# Altium schematic sheet 1.SchDoc
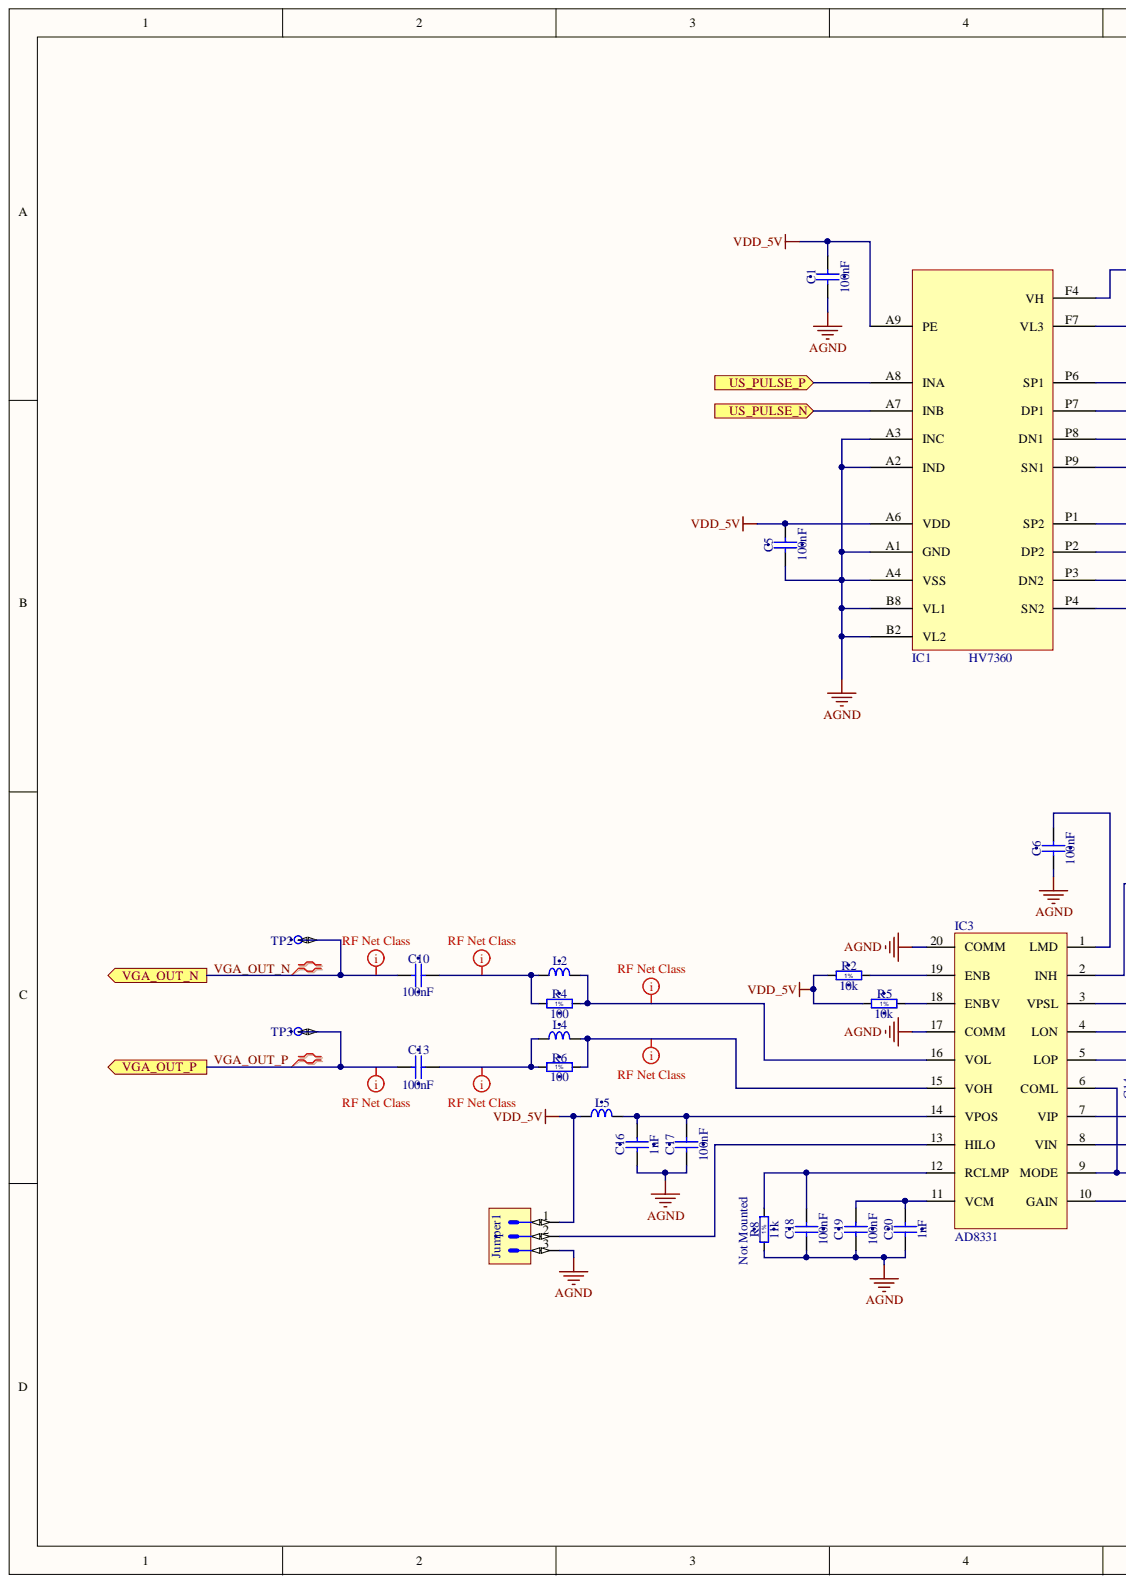
[diagram p0: 1.SchDoc, left]
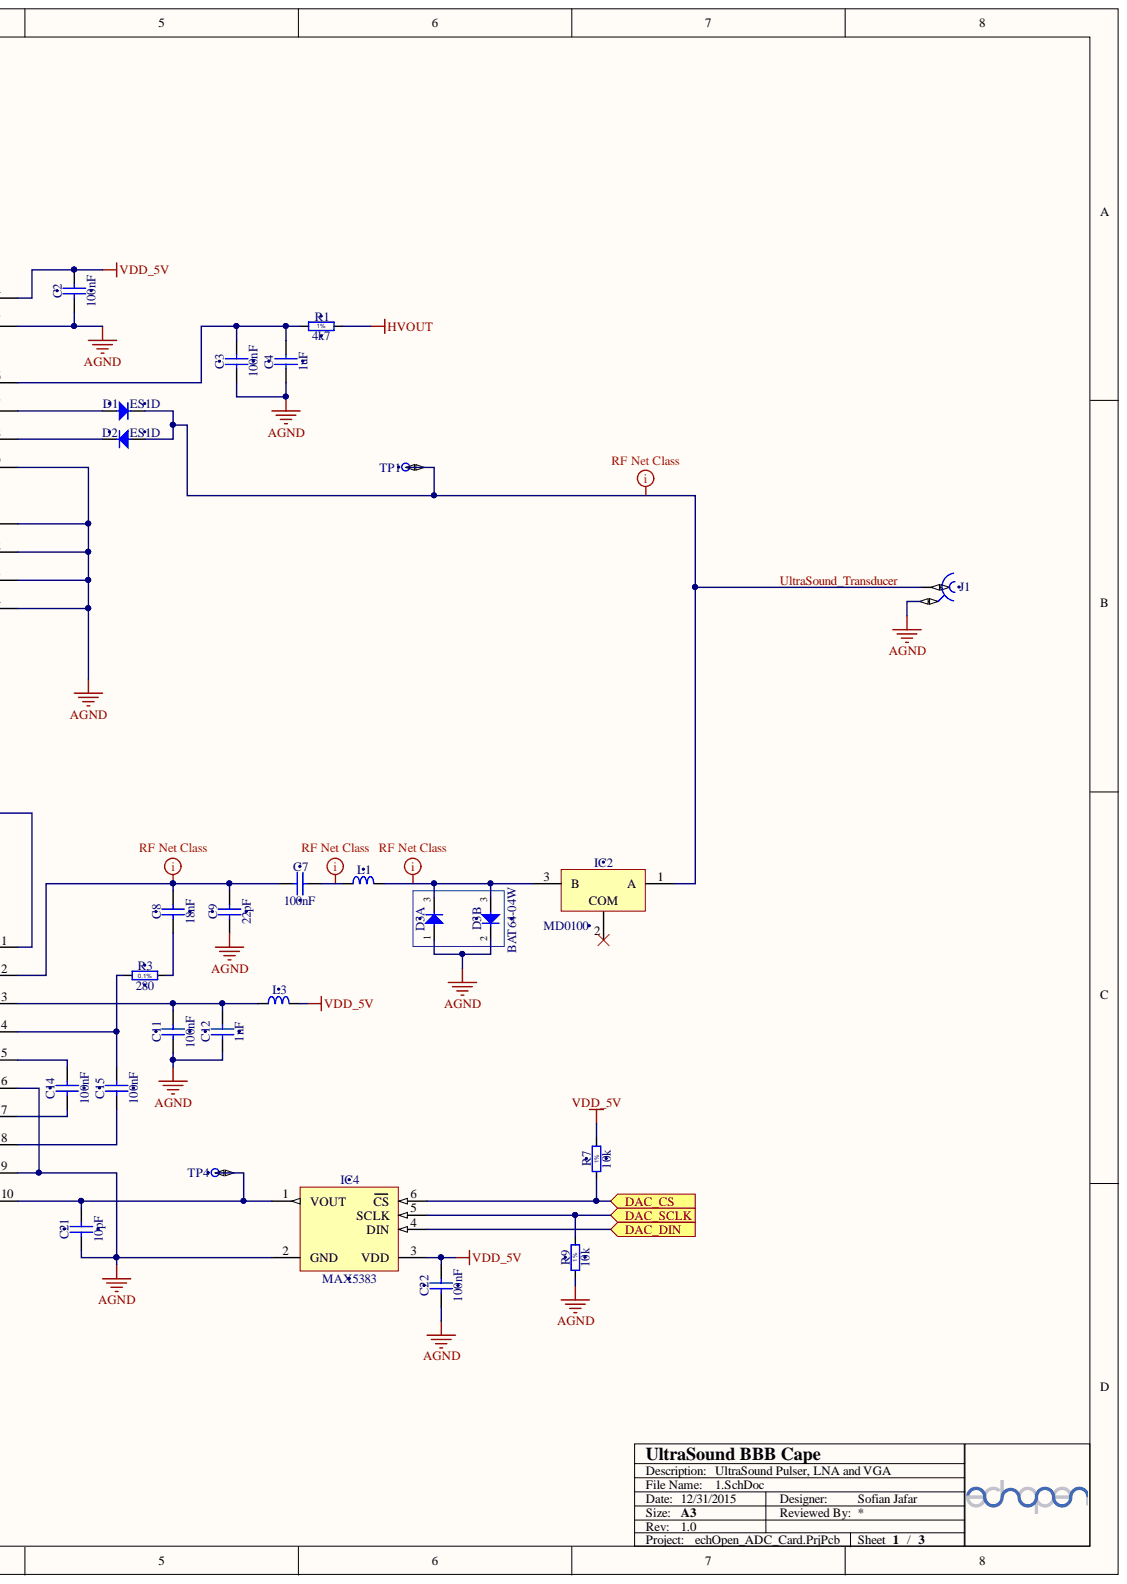
[diagram p1: 1.SchDoc, right]

## Parts
C1  100nF  [CAPC1608X87N]
C2  100nF  [CAPC1608X87N]
C3  100nF  [CAPC1608X87N]
C4  1uF  [CAPC5650X165N]
C5  100nF  [CAPC1608X87N]
C6  100nF  [CAPC1608X87N]
C7  100nF  [CAPC1608X87N]
C8  18nF  [CAPC1608X87N]
C9  22pF  [CAPC1608X87N]
C10  100nF  [CAPC1608X87N]
C11  100nF  [CAPC1608X87N]
C12  1nF  [CAPC1608X87N]
C13  100nF  [CAPC1608X87N]
C14  100nF  [CAPC1608X87N]
C15  100nF  [CAPC1608X87N]
C16  1nF  [CAPC1608X87N]
C17  100nF  [CAPC1608X87N]
C18  100nF  [CAPC1608X87N]
C19  100nF  [CAPC1608X87N]
C20  1nF  [CAPC1608X87N]
C21  10pF  [CAPC1608X87N]
C22  100nF  [CAPC1608X87N]
D1  ES1D  [DIOM5027X244N]
D2  ES1D  [DIOM5027X244N]
D3  BAT64-04W  [SOT65P210X100-3N]  (parts A,B)
IC1  HV7360  [HV7360]
IC2  MD0100  [SOT89]
IC3  AD8331  [SOP64P600X175-20N]
IC4  MAX5383  [SOT65P210X100-6N]
J1  SMA  [AMPHENOL_132357-11]  (value not drawn)
Jumper1  Family  [SULLINS_GRPB031VWVN]  (value not drawn)
L1  75R@100MHz  [INDC2012X105N]  (value not drawn)
L2  75R@100MHz  [INDC2012X105N]  (value not drawn)
L3  75R@100MHz  [INDC2012X105N]  (value not drawn)
L4  75R@100MHz  [INDC2012X105N]  (value not drawn)
L5  75R@100MHz  [INDC2012X105N]  (value not drawn)
R1  4k7  [RESC3216X65N]
R2  10k  [RESC1608X55N]
R3  280  [RESC1608X55N]
R4  100  [RESC1608X55N]
R5  10k  [RESC1608X55N]
R6  100  [RESC1608X55N]
R7  10k  [RESC1608X55N]
R8  11k  Mounted: Not Mounted  [RESC1005X40N]
R9  10k  [RESC1608X55N]
TP1  Test Point  [NICOMATIC_C12000B]  (value not drawn)
TP2  Test Point  [NICOMATIC_C12000B]  (value not drawn)
TP3  Test Point  [NICOMATIC_C12000B]  (value not drawn)
TP4  Test Point  [NICOMATIC_C12000B]  (value not drawn)

## Ports
DAC_CS, DAC_DIN, DAC_SCLK, US_PULSE_N, US_PULSE_P, VGA_OUT_N, VGA_OUT_P

## Nets
AGND: C1.1 C2.1 C3.1 C4.1 C5.1 C6.2 C9.1 C11.1 C12.1 C16.1 C17.2 C18.2 C19.2 C20.1 C21.1 C22.1 D3.1 D3.2 IC1.A1(GND) IC1.A2(IND) IC1.A3(INC) IC1.A4(VSS) IC1.B2(VL2) IC1.B8(VL1) IC1.F7(VL3) IC1.P1(SP2) IC1.P2(DP2) IC1.P3(DN2) IC1.P4(SN2) IC1.P9(SN1) IC3.6(COML) IC3.9(MODE) IC3.17(COMM) IC3.20(COMM) IC4.2(GND) J1.2 Jumper1.3 R8.1 R9.1
HVOUT: R1.2
VDD_5V: C1.2 C2.2 C5.2 C22.2 IC1.A6(VDD) IC1.A9(PE) IC1.F4(VH) IC4.3(VDD) Jumper1.1 L3.2 L5.1 R2.1 R5.1 R7.2
DAC_CS: IC4.6(CS) R7.1 ; port DAC_CS
DAC_DIN: IC4.4(DIN) ; port DAC_DIN
DAC_SCLK: IC4.5(SCLK) R9.2 ; port DAC_SCLK
ULTRASOUND_TRANSDUCER: D1.1 D2.2 IC2.1 J1.1 TP1.1
US_PULSE_N: IC1.A7(INB) ; port US_PULSE_N
US_PULSE_P: IC1.A8(INA) ; port US_PULSE_P
VGA_OUT_N: C10.2 TP2.1 ; port VGA_OUT_N
VGA_OUT_P: C13.2 TP3.1 ; port VGA_OUT_P
NetC3_2: C3.2 C4.2 IC1.P6(SP1) R1.1
NetC6_1: C6.1 IC3.1(LMD)
NetC7_1: C7.1 L1.1
NetC7_2: C7.2 C8.2 C9.2 IC3.2(INH)
NetC8_1: C8.1 R3.2
NetC10_1: C10.1 L2.1 R4.1
NetC11_2: C11.2 C12.2 IC3.3(VPSL) L3.1
NetC13_1: C13.1 L4.1 R6.1
NetC14_1: C14.1 IC3.5(LOP)
NetC14_2: C14.2 IC3.7(VIP)
NetC15_1: C15.1 IC3.4(LON) R3.1
NetC15_2: C15.2 IC3.8(VIN)
NetC16_2: C16.2 C17.1 IC3.14(VPOS) L5.2
NetC18_1: C18.1 IC3.12(RCLMP) R8.2
NetC19_1: C19.1 C20.2 IC3.11(VCM)
NetC21_2: C21.2 IC3.10(GAIN) IC4.1(VOUT) TP4.1
NetD1_2: D1.2 IC1.P7(DP1)
NetD2_1: D2.1 IC1.P8(DN1)
NetD3_3: D3.3 IC2.3 L1.2
NetIC3_13: IC3.13(HILO) Jumper1.2
NetIC3_15: IC3.15(VOH) L4.2 R6.2
NetIC3_16: IC3.16(VOL) L2.2 R4.2
NetIC3_18: IC3.18(ENBV) R5.2
NetIC3_19: IC3.19(ENB) R2.2

Not connected: IC2 2

## Library data of the parts
C1-C3 C5-C7 C10 C11 C13-C15 C17-C19 C22 = CC0603_100NF_50V_10%_X7R: Non-polarised capacitor; Manufacturer: GENERIC; Manufacturer1 Part Number: 223858615649; Part Description: SMD Multilayer Chip Ceramic Capacitor; Database Table Name: Capacitors SMD; TC: X7R; Tolerance: ±10%; Voltage: 50V; Case: 0603; Component Kind: Standard; Component Type: Standard; CreateDate: 12/3/2007; HelpURL: \\cern.ch\dfs\Applications\Altium\Datasheets\CC0603_X7R_PHYCOMP.pdf; LatestRevisionDate: 12/3/2007; Manufacturer1 ComponentHeight: 0.87mm; Manufacturer1 Example: PHYCOMP; Manufacturer Part Number: CC0603_100NF_50V_10%_X7R; Mounted: Yes; Part Number: CC0603_100NF_50V_10%_X7R; Pin Count: 2; SMD: Yes; Socket: No; Status: Preferred; Table: Capacitors SMD; Value: 100nF; Author: CERN DEM JLC; Library Name: Sof-Capacitors.DbLib
C4 = CC2220_1UF_200V_10%_X7R: Non-polarised capacitor; Manufacturer: GENERIC; Manufacturer1 Part Number: C2220X105K2RAC; Part Description: SMD Ceramic Chip Capacitor; Database Table Name: Capacitors SMD; TC: X7R; Tolerance: ±10%; Voltage: 200V; Case: 2220; Component Kind: Standard; Component Type: Standard; CreateDate: 9/16/2009; HelpURL: \\cern.ch\dfs\Applications\Altium\Datasheets\CC2220_X7R_KEMET.pdf; LatestRevisionDate: 9/16/2009; Manufacturer1 ComponentHeight: 1.65mm; Manufacturer1 Example: KEMET; Manufacturer Part Number: CC2220_1UF_200V_10%_X7R; Mounted: Yes; Part Number: CC2220_1UF_200V_10%_X7R; Pin Count: 2; SMD: Yes; Socket: No; Status: None; Table: Capacitors SMD; Value: 1uF; Author: CERN DEM JLC; Library Name: Sof-Capacitors.DbLib
C8 = CC0603_18NF_50V_10%_X7R: Non-polarised capacitor; Manufacturer: GENERIC; Manufacturer1 Part Number: 223858615639; Part Description: SMD Multilayer Chip Ceramic Capacitor; Database Table Name: Capacitors SMD; TC: X7R; Tolerance: ±10%; Voltage: 50V; Case: 0603; Component Kind: Standard; Component Type: Standard; CreateDate: 12/3/2007; HelpURL: \\cern.ch\dfs\Applications\Altium\Datasheets\CC0603_X7R_PHYCOMP.pdf; LatestRevisionDate: 12/3/2007; Manufacturer1 ComponentHeight: 0.87mm; Manufacturer1 Example: PHYCOMP; Manufacturer Part Number: CC0603_18NF_50V_10%_X7R; Mounted: Yes; Part Number: CC0603_18NF_50V_10%_X7R; Pin Count: 2; SMD: Yes; Socket: No; Status: Preferred; Table: Capacitors SMD; Value: 18nF; Author: CERN DEM JLC; Library Name: Sof-Capacitors.DbLib
C9 = CC0603_22PF_50V_5%_NP0: Non-polarised capacitor; Manufacturer: GENERIC; Manufacturer1 Part Number: 223886715229; Part Description: SMD Multilayer Chip Ceramic Capacitor; Database Table Name: Capacitors SMD; TC: NP0; Tolerance: ±5%; Voltage: 50V; Case: 0603; Component Kind: Standard; Component Type: Standard; CreateDate: 12/3/2007; HelpURL: \\cern.ch\dfs\Applications\Altium\Datasheets\CC0603_NP0_PHYCOMP.pdf; LatestRevisionDate: 12/3/2007; Manufacturer1 ComponentHeight: 0.87mm; Manufacturer1 Example: PHYCOMP; Manufacturer Part Number: CC0603_22PF_50V_5%_NP0; Mounted: Yes; Part Number: CC0603_22PF_50V_5%_NP0; Pin Count: 2; SMD: Yes; Socket: No; Status: Preferred; Table: Capacitors SMD; Value: 22pF; Author: CERN DEM JLC; Library Name: Sof-Capacitors.DbLib
C12 C16 C20 = CC0603_1NF_50V_10%_X7R: Non-polarised capacitor; Manufacturer: GENERIC; Manufacturer1 Part Number: 223858615623; Part Description: SMD Multilayer Chip Ceramic Capacitor; Database Table Name: Capacitors SMD; TC: X7R; Tolerance: ±10%; Voltage: 50V; Case: 0603; Component Kind: Standard; Component Type: Standard; CreateDate: 12/3/2007; HelpURL: \\cern.ch\dfs\Applications\Altium\Datasheets\CC0603_X7R_PHYCOMP.pdf; LatestRevisionDate: 12/3/2007; Manufacturer1 ComponentHeight: 0.87mm; Manufacturer1 Example: PHYCOMP; Manufacturer Part Number: CC0603_1NF_50V_10%_X7R; Mounted: Yes; Part Number: CC0603_1NF_50V_10%_X7R; Pin Count: 2; SMD: Yes; Socket: No; Status: Preferred; Table: Capacitors SMD; Value: 1nF; Author: CERN DEM JLC; Library Name: Sof-Capacitors.DbLib
C21 = CC0603_10PF_50V_5%_NP0: Non-polarised capacitor; Manufacturer: GENERIC; Manufacturer1 Part Number: 223886715109; Part Description: SMD Multilayer Chip Ceramic Capacitor; Database Table Name: Capacitors SMD; TC: NP0; Tolerance: ±5%; Voltage: 50V; Case: 0603; Component Kind: Standard; Component Type: Standard; CreateDate: 12/3/2007; HelpURL: \\cern.ch\dfs\Applications\Altium\Datasheets\CC0603_NP0_PHYCOMP.pdf; LatestRevisionDate: 12/3/2007; Manufacturer1 ComponentHeight: 0.87mm; Manufacturer1 Example: PHYCOMP; Manufacturer Part Number: CC0603_10PF_50V_5%_NP0; Mounted: Yes; Part Number: CC0603_10PF_50V_5%_NP0; Pin Count: 2; SMD: Yes; Socket: No; Status: Preferred; Table: Capacitors SMD; Value: 10pF; Author: CERN DEM JLC; Library Name: Sof-Capacitors.DbLib
D1 D2 = ES1D: Diode; Manufacturer: FAIRCHILD SEMICONDUCTOR; Part Description: Fast Rectifier; Database Table Name: Diodes; Power: 1A; Voltage: 200V; Case: DO-214AC; ComponentHeight: 2.44mm; Component Kind: Standard; Component Type: Standard; CreateDate: 5/6/2008; Device: ES1D; HelpURL: \\cern.ch\dfs\Applications\Altium\Datasheets\ES1D.pdf; LatestRevisionDate: 5/6/2008; Manufacturer Part Number: ES1D; Mounted: Yes; PackageDescription: DO-214-AC/SMA  Molded Diode, Body 5.0x2.7mm, IPC Medium Density; Part Number: ES1D; Pin Count: 2; SMD: Yes; Socket: No; Table: Diodes; Author: CERN DEM JLC; Library Name: Sof-ICs And Semiconductors.DbLib
D3 = BAT64-04W: Serial Diode; Manufacturer: INFINEON; Part Description: Silicon Schottky Double Diode; Database Table Name: Diodes; Power: 250mW; Voltage: 40V; Case: SOT323; ComponentHeight: 1mm; Component Kind: Standard; Component Type: Standard; CreateDate: 1/8/2009; Device: BAT64-04W; HelpURL: \\cern.ch\dfs\Applications\Altium\Datasheets\BAT64-04W.pdf; LatestRevisionDate: 1/8/2009; Manufacturer Part Number: BAT64-04W; Mounted: Yes; PackageDescription: SOT323, 0.65mm pitch; 3 pin, 1.25mm W X 2.00mm L, IPC Medium Density; Part Number: BAT64-04W; Pin Count: 3; SMD: Yes; Socket: No; Table: Diodes; Author: CERN DEM JMW; Library Name: Sof-ICs And Semiconductors.DbLib
IC1 = HV7360: Manufacturer: Supertex inc.; Part Description: High Speed, ±100V 2.5A Two or Three Level Ultrasound Pulser; Database Table Name: Analog & Interface; Case: LFGA-22; ComponentHeight: 0.85; Component Kind: Standard; Component Type: Standard; CreateDate: 12/20/2015; Device: HV7360; LatestRevisionDate: 12/20/2015; Manufacturer Part Number: HV7360; Mounted: Yes; PackageDescription: High Speed, ±100V 2.5A Two or Three Level Ultrasound Pulser; Part Number: HV7360; Pin Count: 22; SMD: Yes; Socket: No; Table: Analog & Interface; Author: S. Jafar; Library Name: Sof-ICs And Semiconductors.DbLib
IC2 = MD0100: 3-Ultra-Sound HV Switch 1=A 2=COM 3=B; Manufacturer: Supertex inc.; Database Table Name: Analog & Interface; Case: SOT89; ComponentHeight: 1.6mm; Component Kind: Standard; Component Type: Standard; CreateDate: 12/20/2015; Device: MD0100; LatestRevisionDate: 12/20/2015; Manufacturer Part Number: MD0100; Mounted: Yes; PackageDescription: SOT89-3, 3-Leads, Body 2.44x4.5mm; Part Description: Ultra-Sound HV Switch; Part Number: MD0100; Pin Count: 4; SMD: Yes; Socket: No; Table: Analog & Interface; Author: S. Jafar; Library Name: Sof-ICs And Semiconductors.DbLib
IC3 = AD8331: Manufacturer: Analog Devices; Part Description: Ultralow Noise VGAs with Preamplifier and Programmable RIN; Database Table Name: Analog & Interface; Case: QSOP-20; ComponentHeight: 1.75mm; Component Kind: Standard; Component Type: Standard; CreateDate: 12/20/2015; Device: AD8331; LatestRevisionDate: 12/20/2015; Manufacturer Part Number: AD8331; Mounted: Yes; PackageDescription: SOP, 20-Leads, Body 8.66x3.91mm, Pitch 0.64mm, IPC Medium Density; Part Number: AD8331; Pin Count: 20; SMD: Yes; Socket: No; Table: Analog & Interface; Author: S. Jafar; Library Name: Sof-ICs And Semiconductors.DbLib
IC4 = MAX5383: Low-Cost, Low-Power, 8-Bit DACs with 3-Wire  Serial Interface in SOT23; Manufacturer: MAXIM; Manufacturer Part Number: AD5622AKSZ-2500RL7; Database Table Name: Analog & Interface; Case: SOT363; ComponentHeight: 1.0mm; Component Kind: Standard; Component Type: Standard; CreateDate: 12/23/2015; Device: MAX5383; LatestRevisionDate: 12/23/2015; Mounted: Yes; PackageDescription: SOT363, SC70-6, SOT, 0.65mm Pitch, 6 pin, 1.25mm W X 2.00mm L X 1.10mm H, IPC Medium Density; Part Description: Low-Cost, Low-Power, 8-Bit DACs with 3-Wire  Serial Interface in SOT23; Part Number: MAX5383; Pin Count: 6; SMD: Yes; Socket: No; Table: Analog & Interface; Author: S. Jafar; Library Name: Sof-ICs And Semiconductors.DbLib
J1 = AMPHENOL_132357-11: Part Description: SMA End Launch Jack for 1.58mm PCB, 50 Ohm, Flat Tab Contact; ComponentHeight: 7.92mm; Component Kind: Standard; Component Type: Standard; CreateDate: 5/14/2012; Database Table Name: AMPHENOL; Family: SMA; HelpURL: \\cern.ch\dfs\Applications\Altium\Datasheets\AMPHENOL_132357-11.pdf; LatestRevisionDate: 5/14/2012; Manufacturer: AMPHENOL; Manufacturer Part Number: 132357-11; Mounted: Yes; Part Number: AMPHENOL_132357-11; Pin Count: 2; SMD: Yes; Socket: No; Status: None; Table: AMPHENOL; Author: CERN DEM JLC; Library Name: Sof-Connectors.DbLib
Jumper1 = SULLINS_GRPB031VWVN: Connector 3 Male; Part Description: 3 Contact 0.5" Pitch Single-In-Line Vertical Header; Database Table Name: Miscellaneous; ComponentHeight: 4mm; Component Kind: Standard; Component Type: Standard; CreateDate: 12/29/2015; LatestRevisionDate: 12/29/2015; Manufacturer: SULLINS; Manufacturer Part Number: GRPB031VWVN; Mounted: Yes; Part Number: SULLINS_GRPB031VWVN; Pin Count: 3; SMD: No; Socket: No; Status: None; Table: Miscellaneous; Author: S. Jafar; Library Name: Sof-Connectors.DbLib
L1-L5 = IND0805_MURATA_BLM21BB750SN1D: Inductor; Manufacturer: MURATA; Part Description: SMD EMIFIL Suppression Chip Ferrite Bead; Database Table Name: Inductors SMD; Power: 700mA; Resistance: 0.16R; Tolerance: ±25%; Case: 0805; ComponentHeight: 1.05mm; Component Kind: Standard; Component Type: Standard; CreateDate: 12/21/2015; LatestRevisionDate: 12/21/2015; Manufacturer Part Number: BLM21BB750SN1D; Mounted: Yes; Part Number: IND0805_MURATA_BLM21BB750SN1D; Pin Count: 2; SMD: Yes; Socket: No; Status: None; Table: Inductors SMD; Value: 75R@100MHz; Author: S. Jafar; Library Name: Sof-Inductors & Transformers.DbLib
R1 = R1206_4K7_1%_0.25W_100PPM: Resistor - 1%; Manufacturer: GENERIC; Manufacturer1 Part Number: NRC12F4701TRF; Part Description: General Purpose Thick Film Chip Resistor; Database Table Name: Resistors SMD; Power: 0.25W; TC: ±100ppm/°C; Case: 1206; Component Kind: Standard; Component Type: Standard; CreateDate: 12/3/2007; HelpURL: \\cern.ch\dfs\Applications\Altium\Datasheets\R1206_NIC_NRC.pdf; LatestRevisionDate: 3/13/2008; Manufacturer1 ComponentHeight: 0.65mm; Manufacturer1 Example: NIC COMPONENT; Manufacturer Part Number: R1206_4K7_1%_0.25W_100PPM; Mounted: Yes; Part Number: R1206_4K7_1%_0.25W_100PPM; Pin Count: 2; SMD: Yes; Socket: No; Status: Preferred; Table: Resistors SMD; Tolerance: ±1%; Value: 4k7; Author: CERN DEM JLC; Library Name: Sof-Resistors.DbLib
R2 R5 R7 R9 = R0603_10K_1%_0.1W_100PPM: Resistor - 1%; Manufacturer: GENERIC; Manufacturer1 Part Number: NRC06F1002TRF; Part Description: General Purpose Thick Film Chip Resistor; Database Table Name: Resistors SMD; Power: 0.1W; TC: ±100ppm/°C; Case: 0603; Component Kind: Standard; Component Type: Standard; CreateDate: 12/3/2007; HelpURL: \\cern.ch\dfs\Applications\Altium\Datasheets\R0603_NIC_NRC.pdf; LatestRevisionDate: 3/13/2008; Manufacturer1 ComponentHeight: 0.55mm; Manufacturer1 Example: NIC COMPONENT; Manufacturer Part Number: R0603_10K_1%_0.1W_100PPM; Mounted: Yes; Part Number: R0603_10K_1%_0.1W_100PPM; Pin Count: 2; SMD: Yes; Socket: No; Status: Preferred; Table: Resistors SMD; Tolerance: ±1%; Value: 10k; Author: CERN DEM JLC; Library Name: Sof-Resistors.DbLib
R3 = R0603_280R_0.1%_0.063W_10PPM: Resistor - 0.1%; Manufacturer: GENERIC; Manufacturer1 Part Number: RN73C1J280RBTDF; Part Description: Thin Film Chip Resistor; Database Table Name: Resistors SMD; Power: 0.063W; TC: ±10ppm; Case: 0603; Component Kind: Standard; Component Type: Standard; CreateDate: 12/3/2007; HelpURL: \\cern.ch\dfs\Applications\Altium\Datasheets\R0603_Tyco_RN73.pdf; LatestRevisionDate: 3/13/2008; Manufacturer1 ComponentHeight: 0.5mm; Manufacturer1 Example: TYCO HOLSWORTHY; Manufacturer Part Number: R0603_280R_0.1%_0.063W_10PPM; Mounted: Yes; Part Number: R0603_280R_0.1%_0.063W_10PPM; Pin Count: 2; SMD: Yes; Socket: No; Status: Not recommended; Table: Resistors SMD; Tolerance: ±0.1%; Value: 280; Author: CERN DEM JLC; Library Name: Sof-Resistors.DbLib
R4 R6 = R0603_100R_1%_0.1W_100PPM: Resistor - 1%; Manufacturer: GENERIC; Manufacturer1 Part Number: NRC06F4700TRF; Part Description: General Purpose Thick Film Chip Resistor; Database Table Name: Resistors SMD; Power: 0.1W; TC: ±100ppm/°C; Case: 0603; Component Kind: Standard; Component Type: Standard; CreateDate: 12/3/2007; HelpURL: \\cern.ch\dfs\Applications\Altium\Datasheets\R0603_NIC_NRC.pdf; LatestRevisionDate: 3/13/2008; Manufacturer1 ComponentHeight: 0.55mm; Manufacturer1 Example: NIC COMPONENT; Manufacturer Part Number: R0603_100R_1%_0.1W_100PPM; Mounted: Yes; Part Number: R0603_100R_1%_0.1W_100PPM; Pin Count: 2; SMD: Yes; Socket: No; Status: Preferred; Table: Resistors SMD; Tolerance: ±1%; Value: 100; Author: CERN DEM JLC; Library Name: Sof-Resistors.DbLib
R8 = R0402_11K_1%_0.0625W_100PPM: Resistor - 1%; Manufacturer: GENERIC; Manufacturer1 Part Number: 232270671103L; Part Description: General Purpose Thick Film Chip Resistor; Database Table Name: Resistors SMD; TC: ±100ppm/°C; Case: 0402; Component Kind: Standard; Component Type: Standard; CreateDate: 12/3/2007; HelpURL: \\cern.ch\dfs\Applications\Altium\Datasheets\R0402_Phycomp_RC0402.pdf; LatestRevisionDate: 10/17/2012; Manufacturer1 ComponentHeight: 0.4mm; Manufacturer1 Example: YAGEO PHYCOMP; Manufacturer Part Number: R0402_11K_1%_0.0625W_100PPM; Part Number: R0402_11K_1%_0.0625W_100PPM; Pin Count: 2; Power: 0.0625W; SMD: Yes; Socket: No; Status: Not recommended; Table: Resistors SMD; Tolerance: ±1%; Value: 11k; Author: CERN DEM JLC; Library Name: Sof-Resistors.DbLib
TP1-TP4 = NICOMATIC_C12000B: Part Description: SMD Test Point; Database Table Name: Miscellaneous; ComponentHeight: 1.5mm; Component Kind: Standard; Component Type: Standard; CreateDate: 3/25/2009; Description: Test Point; Family: Test Point; HelpURL: \\cern.ch\dfs\Applications\Altium\Datasheets\NICOMATIC_C12000B.pdf; LatestRevisionDate: 3/25/2009; Manufacturer: NICOMATIC; Manufacturer Part Number: C12000B; Mounted: Yes; Part Number: NICOMATIC_C12000B; Pin Count: 1; SMD: Yes; Socket: No; Status: None; Table: Miscellaneous; Author: CERN DEM JLC; Library Name: Sof-Connectors.DbLib
